# Altium schematic sheet RPI-8x8 RGB Matrix_1.SchDoc
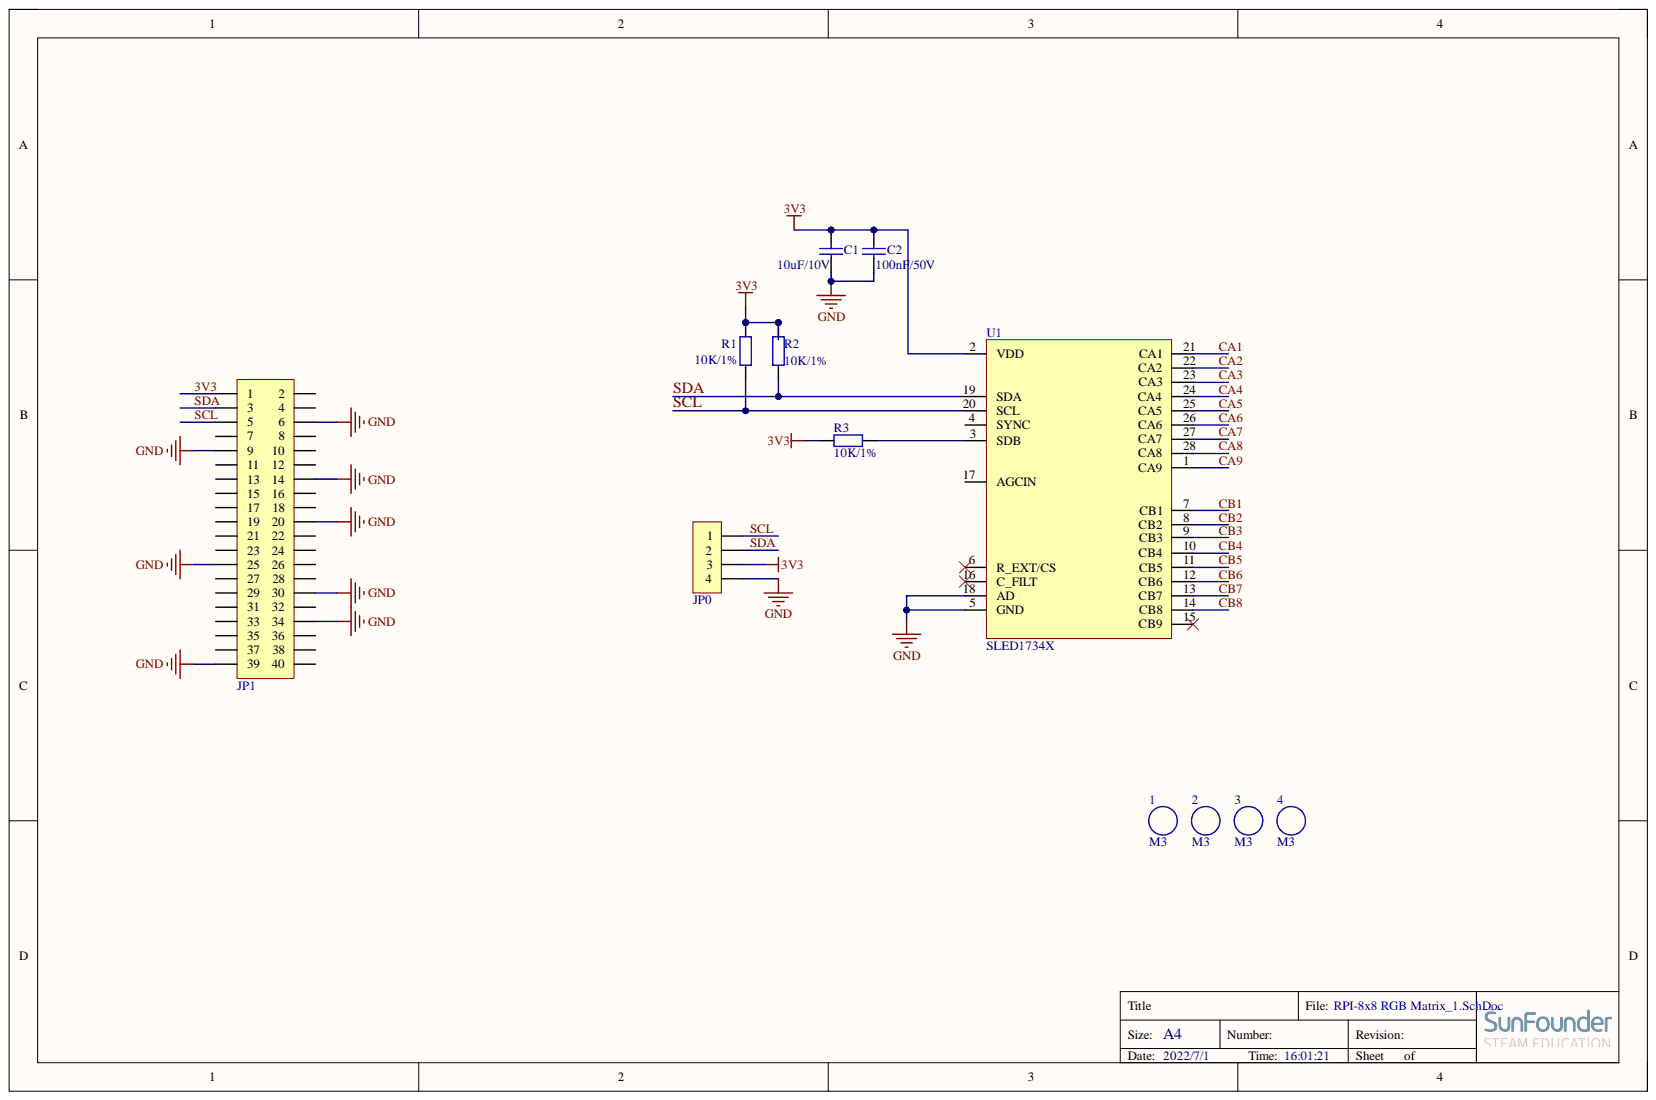
[diagram p0: RPI-8x8 RGB Matrix_1.SchDoc]

## Parts
1  M3  [M3-6x6]
2  M3  [M3-6x6]
3  M3  [M3-6x6]
4  M3  [M3-6x6]
C1  10uF/10V  [0603_C]
C2  100nF/50V  [0603_C]
JP0  HDR-1X4  [SH1.0-4P-WS]  (value not drawn)
JP1  Comment  [HDR2.54-M-LI-2x20P]  (value not drawn)
R1  10K/1%  [0603_R]
R2  10K/1%  [0603_R]
R3  10K/1%  [0603_R]
U1  SLED1734X  [SSOP-28_208mil]

## Nets
GND: C1.1 C2.1 JP0.4 JP1.6 JP1.9 JP1.14 JP1.20 JP1.25 JP1.30 JP1.34 JP1.39 U1.5(GND) U1.18(AD)
3V3: C1.2 C2.2 JP0.3 JP1.1 R1.2 R2.2 R3.2 U1.2(VDD)
CA1: U1.21(CA1)
CA2: U1.22(CA2)
CA3: U1.23(CA3)
CA4: U1.24(CA4)
CA5: U1.25(CA5)
CA6: U1.26(CA6)
CA7: U1.27(CA7)
CA8: U1.28(CA8)
CA9: U1.1(CA9)
CB1: U1.7(CB1)
CB2: U1.8(CB2)
CB3: U1.9(CB3)
CB4: U1.10(CB4)
CB5: U1.11(CB5)
CB6: U1.12(CB6)
CB7: U1.13(CB7)
CB8: U1.14(CB8)
SCL: JP0.1 JP1.5 R1.1 U1.20(SCL)
SDA: JP0.2 JP1.3 R2.1 U1.19(SDA)
NetR3_1: R3.1 U1.3(SDB)

Not connected: JP1 2 4 7 8 10 11 12 13 15 16 17 18 19 21 22 23 24 26 27 28 29 31 32 33 35 36 37 38 40; U1 4(SYNC) 6(R_EXT/CS) 15(CB9) 16(C_FILT) 17(AGCIN)

## Library data of the parts
1-4 = M3: M3螺丝孔; BOM名称: 铜柱孔; 版权归属: 源创客; Component Kind: Standard; 学习资源: www.ooocke.com; Library Name: RPI_8x8RGB dot matrix screen.SchLib
C1 C2 = 0603_C: 贴片电容; Component Kind: Standard; ComponentLink1Description: 供应商链接; ComponentLink1URL: http://www.szlcsc.com/product/details_20411.html; Package: 0603; Supplier: LC; SuppliersPartNumber: C19702; Library Name: RPI_8x8RGB dot matrix screen.SchLib
JP0 = Header 4: 4P接插件; 版权归属: 源创客; BOM名称: 4P接插件; Component Kind: Standard; Library Name: ESP32 HAT.SchLib; 学习资源: www.ooocke.com
JP1 = Header 20X2: 20*2P接插件; 版权归属: 源创客; BOM名称: 20*2P接插件; Component Kind: Standard; 学习资源: www.ooocke.com; Library Name: RPI_8x8RGB dot matrix screen.SchLib
R1-R3 = 0603_R: 贴片电阻; Component Kind: Standard; ComponentLink1Description: 供应商链接; ComponentLink1URL: http://www.szlcsc.com/product/details_23889.html; Package: 0603; Supplier: LC; SuppliersPartNumber: C23162; Library Name: RPI_8x8RGB dot matrix screen.SchLib
U1 = SLED1734X: Component Kind: Standard; Library Name: RGB dot matrix screen.SchLib
